# Altium schematic sheet REUN.468349.001_PSU_Board_Button.SchDoc
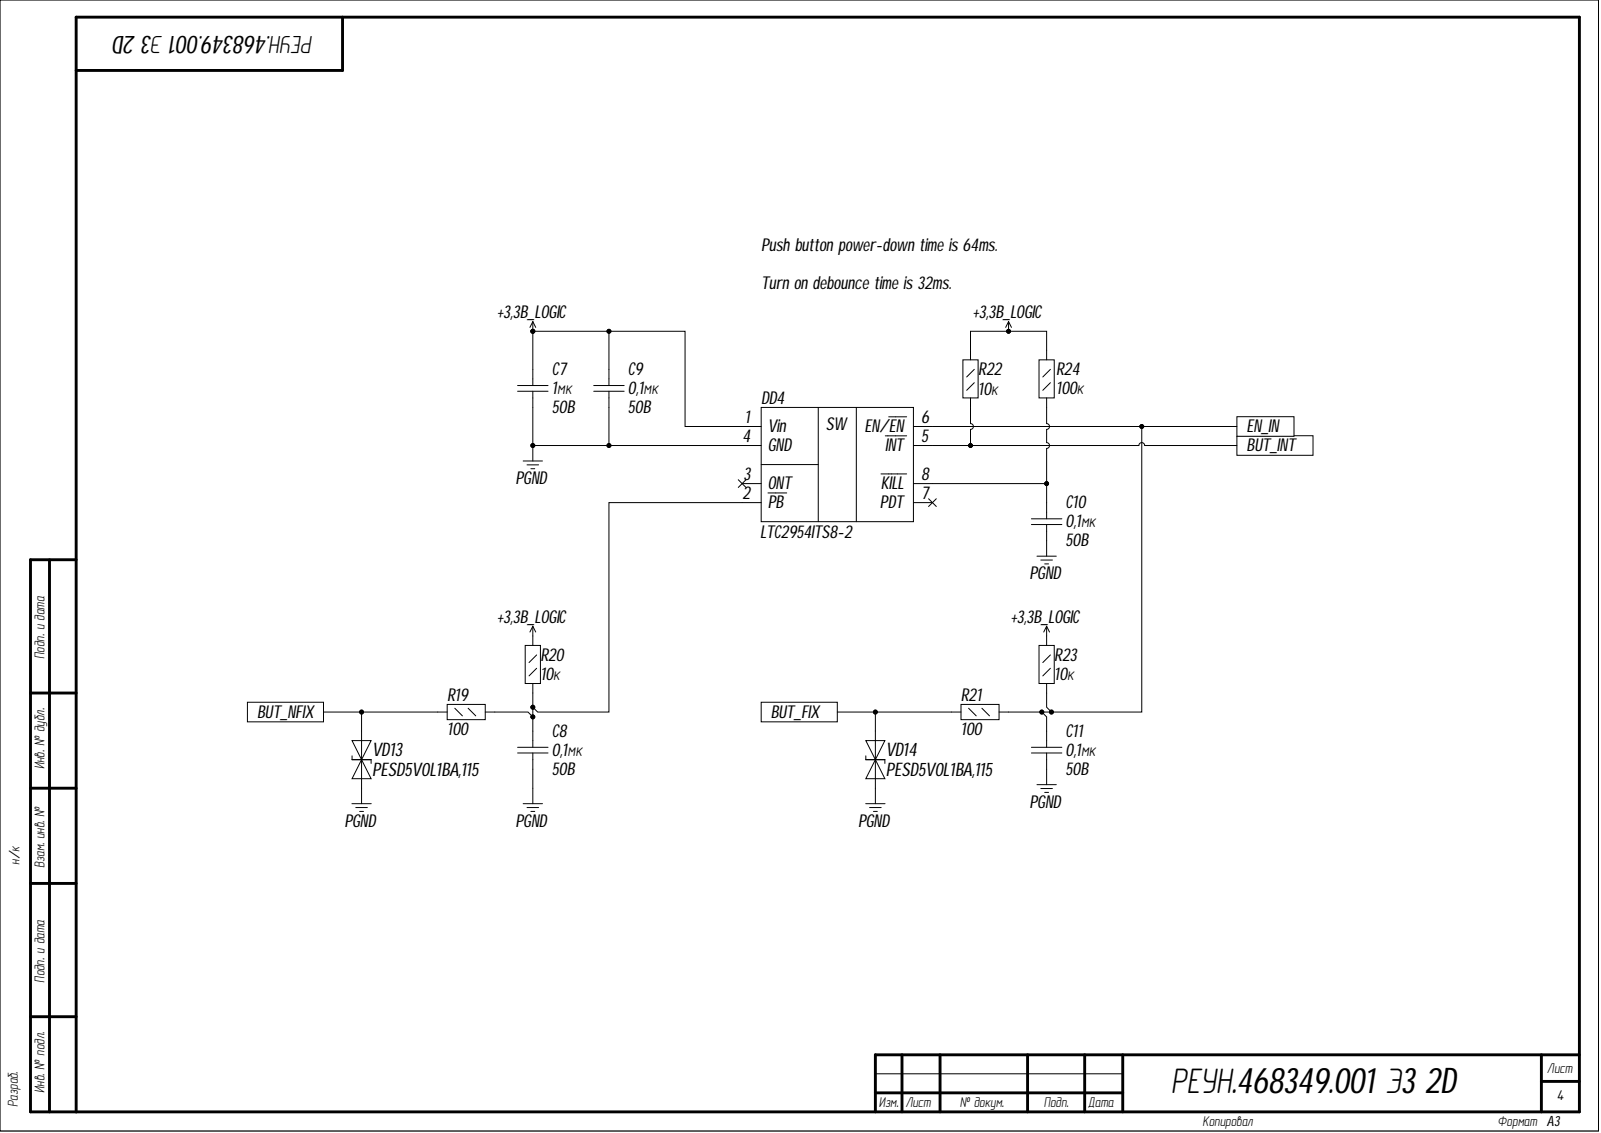
[diagram p0: REUN.468349.001_PSU_Board_Button.SchDoc]

## Parts
C7  1мк  Voltage: 50B  [C0805]
C8  0,1мк  Voltage: 50B  [C0805]
C9  0,1мк  Voltage: 50B  [C0805]
C10  0,1мк  Voltage: 50B  [C0805]
C11  0,1мк  Voltage: 50B  [C0805]
DD4  LTC2954ITS8-2  [SOT-23-8]
R19  value 100  [R0805]  (hidden comment: Resistor_0,125Вт)
R20  value 10к  [R0805]  (hidden comment: Resistor_0,125Вт)
R21  value 100  [R0805]  (hidden comment: Resistor_0,125Вт)
R22  value 10к  [R0805]  (hidden comment: Resistor_0,125Вт)
R23  value 10к  [R0805]  (hidden comment: Resistor_0,125Вт)
R24  value 100к  [R0805]  (hidden comment: Resistor_0,125Вт)
VD13  PESD5V0L1BA,115  [SOD-323]
VD14  PESD5V0L1BA,115  [SOD-323]

## Ports
BUT_FIX, BUT_INT, BUT_NFIX, EN_IN

## Nets
+3,3B_LOGIC: C7.2 C9.2 DD4.1(Vin) R20.2 R22.2 R23.2 R24.2
PGND: C7.1 C8.1 C9.1 C10.1 C11.1 DD4.4(GND) VD13.2 VD14.2
BUT_FIX: R21.1 VD14.1 ; port BUT_FIX
BUT_INT: DD4.5(INT) R22.1 ; port BUT_INT
BUT_NFIX: R19.1 VD13.1 ; port BUT_NFIX
EN_IN: C11.2 DD4.6(EN/EN) R21.2 R23.1 ; port EN_IN
NetC8_2: C8.2 DD4.2(PB) R19.2 R20.1
NetC10_2: C10.2 DD4.8(KILL) R24.1

Not connected: DD4 3(ONT) 7(PDT)

## Library data of the parts
C7 = cap151: Конденсатор; Manufacture: Murata; Part Name: Ceramic; Part Number: GRM21BR71H105KA12L; Database Table Name: Capacitors$; TKE: X7R; Component Kind: Standard; id: cap151; Library Name: MOP44.DbLib; Value: 1мк
C8-C11 = cap141: Конденсатор; Manufacture: Murata; Part Name: Ceramic; Part Number: GRM21BR71H104KA01K; Database Table Name: Capacitors$; TKE: X7R; Component Kind: Standard; id: cap141; Library Name: MOP44.DbLib; Value: 0,1мк
DD4 = btn11: Pushbutton On/Off Controller with µP Interrupt; Manufacture: Analog Devices; Database Table Name: Button$; Component Kind: Standard; id: btn11; Library Name: MOP44.DbLib; Part Name: Pushbutton On/Off Controller; Part Number: LTC2954ITS8-2
R19 = res49: Резистор; Manufacture: Yageo; Part Number: RC0805FR-07100RL; Accuracy: 0.01; Component Kind: Standard; id: res49; Part Name: Resistor; Power: 0,125Вт; Library Name: REUN.468349.001_PSU_Board.SchLib
R20 R22 R23 = res101: Резистор; Manufacture: Yageo; Part Number: RC0805FR-0710KL; Accuracy: 0.01; Database Table Name: Resistor$; Component Kind: Standard; id: res101; Library Name: MOP44.DbLib; Part Name: Resistor; Power: 0,125Вт
R21 = res49: Резистор; Manufacture: Yageo; Part Number: RC0805FR-07100RL; Accuracy: 0.01; Database Table Name: Resistor$; Component Kind: Standard; id: res49; Library Name: MOP44.DbLib; Part Name: Resistor; Power: 0,125Вт
R24 = res125: Резистор; Manufacture: Yageo; Part Number: RC0805FR-07100KL; Accuracy: 0.01; Database Table Name: Resistor$; Component Kind: Standard; id: res125; Library Name: MOP44.DbLib; Part Name: Resistor; Power: 0,125Вт
VD13 VD14 = PESD5V0L1BA,115: Cтабилитрон (диод выпрямительный лавинный) двусторонний; Manufacture: Nexperia; Part Name: TVS diode Bidirectional; Breakdown Voltage: 8,2B; Clamping voltage: 10B; Database Table Name: TVSdiode$; id: tvs286; Leakage Current: 1uA; Number of diodes: 1; Peak Pulse Power: 500W; Stand-off Voltage: 5B; Component Kind: Standard; Library Name: MOP44.DbLib; Part Number: PESD5V0L1BA,115
